# Altium schematic sheet AI8051U-34K64_LQFP48.SchDoc
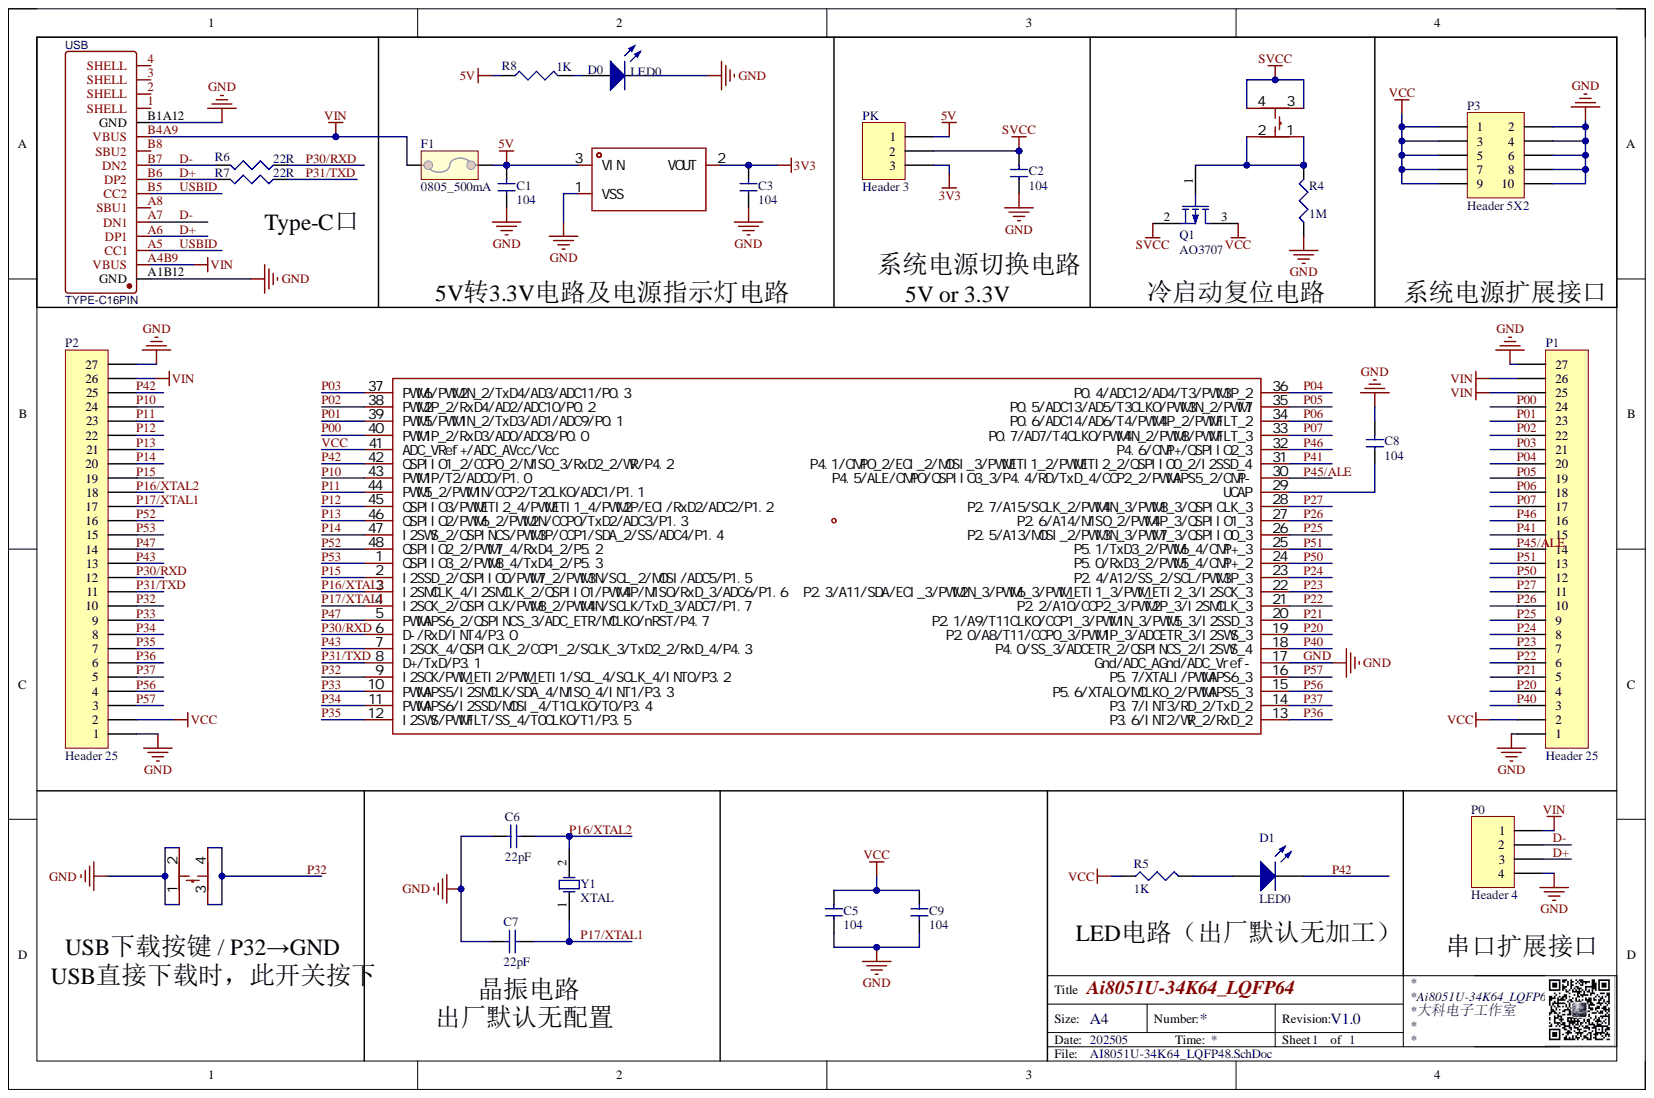
[diagram p0: AI8051U-34K64_LQFP48.SchDoc]

## Parts
C1  104  [C0805]
C2  104  [C0805]
C3  104  [C0805]
C5  104  [C0805]
C6  value 22pF  [C0805]  (hidden comment: Cap)
C7  22pF  [C0805]
C8  104  [C0805]
C9  104  [C0805]
D0  LED0  [D0805]
D1  LED0  [D0805]
F1  0805_500mA  [1206C]
P0  Header 4  [HDR1X4]
P1  Header 25  [HDR1X27]
P2  Header 25  [HDR1X27]
P3  Header 5X2  [HDR2X5-]
PK  Header 3  [HDR-TH_3P-P2.54-V-F]
Q1  AO3707  [SOT-23-3_L2.9-W1.6-P1.90-LS2.8-BR]
Q2  ME6211A33M3G-N  [SOT-23-3_L2.9-W1.6-P1.90-LS2.8-BR]  (value not drawn)
R4  value 1M  [R0805]
R5  value 1K  [R0805]
R6  value 22R  [R0805]
R7  value 22R  [R0805]
R8  value 1K  [R0805]
RST  TS3425BA  [SW-SMD_4P-L4.2-W3.2-P2.15-LS4.6-1]  (value not drawn)
SW  TS3425BA  [SW-SMD_4P-L4.2-W3.2-P2.15-LS4.6-1]  (value not drawn)
U1  AI8051U-34K64-QFP48  [LQFP48]  (value not drawn)
USB  TYPE-C16PIN  [TYPE-C]
Y1  XTAL  [X-SM49]

## Nets
3V3: C3.2 PK.3 Q2.2
5V: C1.2 F1.2 PK.1 Q2.3 R8.1
SVCC: C2.2 PK.2 Q1.2 RST.3 RST.4
VIN: F1.1 P0.1 P1.25 P1.26 P2.26 USB.A4B9(VBUS) USB.B4A9(VBUS)
D+: P0.3 R7.2 USB.A6(DP1) USB.B6(DP2)
D-: P0.2 R6.2 USB.A7(DN1) USB.B7(DN2)
GND: C1.1 C2.1 C3.1 C5.1 C6.1 C7.1 C8.2 C9.1 D0.2 P0.4 P1.1 P1.27 P2.1 P2.27 P3.2 P3.4 P3.6 P3.8 P3.10 Q2.1 R4.1 SW.1 SW.2 U1.17(Gnd/ADC_AGnd/ADC_Vref-) USB.A1B12(GND) USB.B1A12(GND)
P00: P1.24 U1.40(PWM1P_2/RxD3/AD0/ADC8/P0.0)
P01: P1.23 U1.39(PWM5/PWM1N_2/TxD3/AD1/ADC9/P0.1)
P02: P1.22 U1.38(PWM2P_2/RxD4/AD2/ADC10/P0.2)
P03: P1.21 U1.37(PWM6/PWM2N_2/TxD4/AD3/ADC11/P0.3)
P04: P1.20 U1.36(P0.4/ADC12/AD4/T3/PWM3P_2)
P05: P1.19 U1.35(P0.5/ADC13/AD5/T3CLKO/PWM3N_2/PWM7)
P06: P1.18 U1.34(P0.6/ADC14/AD6/T4/PWM4P_2/PWMFLT_2)
P07: P1.17 U1.33(P0.7/AD7/T4CLKO/PWM4N_2/PWM8/PWMFLT_3)
P10: P2.24 U1.43(PWM1P/T2/ADC0/P1.0)
P11: P2.23 U1.44(PWM5_2/PWM1N/CCP2/T2CLKO/ADC1/P1.1)
P12: P2.22 U1.45(QSPIIO3/PWMETI2_4/PWMETI1_4/PWM2P/ECI/RxD2/ADC2/P1.2)
P13: P2.21 U1.46(QSPIIO2/PWM6_2/PWM2N/CCP0/TxD2/ADC3/P1.3)
P14: P2.20 U1.47(I2SWS_2/QSPINCS/PWM3P/CCP1/SDA_2/SS/ADC4/P1.4)
P15: P2.19 U1.2(I2SSD_2/QSPIIO0/PWM7_2/PWM3N/SCL_2/MOSI/ADC5/P1.5)
P16/XTAL2: C6.2 P2.18 U1.3(I2SMCLK_4/I2SMCLK_2/QSPIIO1/PWM4P/MISO/RxD_3/ADC6/P1.6) Y1.2
P17/XTAL1: C7.2 P2.17 U1.4(I2SCK_2/QSPICLK/PWM8_2/PWM4N/SCLK/TxD_3/ADC7/P1.7) Y1.1
P20: P1.4 U1.19(P2.0/A8/T11/CCP0_3/PWM1P_3/ADCETR_3/I2SWS_3)
P21: P1.5 U1.20(P2.1/A9/T11CLKO/CCP1_3/PWM1N_3/PWM5_3/I2SSD_3)
P22: P1.6 U1.21(P2.2/A10/CCP2_3/PWM2P_3/I2SMCLK_3)
P23: P1.7 U1.22(P2.3/A11/SDA/ECI_3/PWM2N_3/PWM6_3/PWM_ETI1_3/PWM_ETI2_3/I2SCK_3)
P24: P1.8 U1.23(P2.4/A12/SS_2/SCL/PWM3P_3)
P25: P1.9 U1.26(P2.5/A13/MOSI_2/PWM3N_3/PWM7_3/QSPIIO0_3)
P26: P1.10 U1.27(P2.6/A14/MISO_2/PWM4P_3/QSPIIO1_3)
P27: P1.11 U1.28(P2.7/A15/SCLK_2/PWM4N_3/PWM8_3/QSPICLK_3)
P30/RXD: P2.12 R6.1 U1.6(D-/RxD/INT4/P3.0)
P31/TXD: P2.11 R7.1 U1.8(D+/TxD/P3.1)
P32: P2.10 SW.3 SW.4 U1.9(I2SCK/PWM_ETI2/PWM_ETI1/SCL_4/SCLK_4/INT0/P3.2)
P33: P2.9 U1.10(PWMAPS5/I2SMCLK/SDA_4/MISO_4/INT1/P3.3)
P34: P2.8 U1.11(PWMAPS6/I2SSD/MOSI_4/T1CLKO/T0/P3.4)
P35: P2.7 U1.12(I2SWS/PWMFLT/SS_4/T0CLKO/T1/P3.5)
P36: P2.6 U1.13(P3.6/INT2/WR_2/RxD_2)
P37: P2.5 U1.14(P3.7/INT3/RD_2/TxD_2)
P40: P1.3 U1.18(P4.0/SS_3/ADCETR_2/QSPINCS_2/I2SWS_4)
P41: P1.15 U1.31(P4.1/CMPO_2/ECI_2/MOSI_3/PWMETI1_2/PWMETI2_2/QSPIIO0_2/I2SSD_4)
P42: D1.2 P2.25 U1.42(QSPIIO1_2/CCP0_2/MISO_3/RxD2_2/WR/P4.2)
P43: P2.13 U1.7(I2SCK_4/QSPICLK_2/CCP1_2/SCLK_3/TxD2_2/RxD_4/P4.3)
P45/ALE: P1.14 U1.30(P4.5/ALE/CMPO/QSPIIO3_3/P4.4/RD/TxD_4/CCP2_2/PWMAPS5_2/CMP-)
P46: P1.16 U1.32(P4.6/CMP+/QSPIIO2_3)
P47: P2.14 U1.5(PWMAPS6_2/QSPINCS_3/ADC_ETR/MCLKO/nRST/P4.7)
P50: P1.12 U1.24(P5.0/RxD3_2/PWM5_4/CMP+_2)
P51: P1.13 U1.25(P5.1/TxD3_2/PWM6_4/CMP+_3)
P52: P2.16 U1.48(QSPIIO2_2/PWM7_4/RxD4_2/P5.2)
P53: P2.15 U1.1(QSPIIO3_2/PWM8_4/TxD4_2/P5.3)
P56: P2.4 U1.15(P5.6/XTALO/MCLKO_2/PWMAPS5_3)
P57: P2.3 U1.16(P5.7/XTALI/PWMAPS6_3)
USBID: USB.A5(CC1) USB.B5(CC2)
VCC: C5.2 C9.2 P1.2 P2.2 P3.1 P3.3 P3.5 P3.7 P3.9 Q1.3 R5.1 U1.41(ADC_VRef+/ADC_AVcc/Vcc)
NetC8_1: C8.1 U1.29(UCAP)
NetD0_1: D0.1 R8.2
NetD1_1: D1.1 R5.2
NetQ1_1: Q1.1 R4.2 RST.1 RST.2

Not connected: USB 1(SHELL) 2(SHELL) 3(SHELL) 4(SHELL) A8(SBU1) B8(SBU2)

## Library data of the parts
C1-C3 C5 C8 C9 = CAP CN: Component Kind: Standard
C6 C7 = Cap: 无极性电容; Component Kind: Standard; LatestRevisionDate: 17-Jul-2002; LatestRevisionNote: Re-released for DXP Platform.; PackageReference: RAD-0.3; Published: 8-Jun-2000; Library Name: Fszhang.IntLib; Publisher: Altium Limited
  C7: Value: 22pF
D0 D1 = LED0: Typical INFRARED GaAs LED; Component Kind: Standard; LatestRevisionDate: 08-Jul-2005; LatestRevisionNote: Mech Layer 1 removed.; Library Name: Miscellaneous Devices.IntLib; PackageDescription: LED; 2 Leads; PackageReference: LED-0; Published: 8-Jun-2000; Simulation: LED0; Publisher: Altium Limited
F1 = Fuse: Component Kind: Standard; Description: Fuse; Library Name: 安中医库2.0.SCHLIB
P0 = Header 4: Header, 4-Pin; Component Kind: Standard; LatestRevisionDate: 17-Jul-2002; LatestRevisionNote: Re-released for DXP Platform.; Library Name: Miscellaneous Connectors.IntLib; Signal Integrity: Connector; Publisher: Altium Limited
P1 P2 = Header 25: Header, 25-Pin; Component Kind: Standard; LatestRevisionDate: 17-Jul-2002; LatestRevisionNote: Re-released for DXP Platform.; Library Name: STC8G_Core.SCHLIB; Signal Integrity: Connector; Publisher: Altium Limited
P3 = Header 5X2: Header, 5-Pin, Dual row; Component Kind: Standard; LatestRevisionDate: 17-Jul-2002; LatestRevisionNote: Re-released for DXP Platform.; Library Name: Miscellaneous Connectors.IntLib; Signal Integrity: Connector; Publisher: Altium Limited
PK = Header 3: Header, 3-Pin; Component Kind: Standard; LatestRevisionDate: 17-Jul-2002; LatestRevisionNote: Re-released for DXP Platform.; Library Name: Miscellaneous Connectors.IntLib; Signal Integrity: Connector; Publisher: Altium Limited
Q1 = MOSFET-P: P-Channel MOSFET; Component Kind: Standard; LatestRevisionDate: 17-Jul-2002; LatestRevisionNote: Re-released for DXP Platform.; Library Name: Miscellaneous Devices.IntLib; PackageDescription: TO, Flat Index; 3 In-Line, Axial Leads; Body Dia. 4.6mm; Lead Dia. 0.5 mm (max); PackageReference: E3; PackageVersion: 1995/1996; Published: 8-Jun-2000; Simulation: PMOS; Publisher: Altium Limited
Q2 = ME6211A33M3G-N: Manufacturer: MICRONE(南京微盟); Add into BOM: yes; Dropout Voltage: 260mV@(200mA); MAX Output Current: 500mA; Maximum Input Voltage: 6V; Number of Outputs: 1; Output Polarity: 正极; Output Type: 固定; Output Voltage: 3.3V; Power Supply Rejection Ratio (PSRR): 70dB; Component Kind: Standard; Convert to PCB: yes; Datasheet: https://atta.szlcsc.com/upload/public/pdf/source/20180224/C168807_15194366627921363679.pdf; Device: ME6211A33M3G-N; JLCPCB Part Class: Extended Part; LCSC Part Name: 输入6V 输出3.3V 500mA; Manufacturer Part: ME6211A33M3G-N; PCB3D: SOT-23-3L_L2.9-W1.6-H1.1-LS2.8-P0.95; Supplier: LCSC; Supplier Footprint: SOT-23; Supplier Part: C168807; Symbol: ME6211A33M3G-N
R4 R6 R7 = Res3: 1M; Component Kind: Standard; LatestRevisionDate: 29-May-2009; LatestRevisionNote: IPC-7351 Footprint Added.; Library Name: Miscellaneous Devices.IntLib; PackageDescription: Chip Resistor; PackageReference: J1-0603; Published: 8-Jun-2000; Signal Integrity: Res; Simulation: RESISTOR; Publisher: Altium Limited
R5 R8 = Res3: Resistor; Component Kind: Standard; LatestRevisionDate: 29-May-2009; LatestRevisionNote: IPC-7351 Footprint Added.; Library Name: Miscellaneous Devices.IntLib; PackageDescription: Chip Resistor; PackageReference: J1-0603; Published: 8-Jun-2000; Signal Integrity: Res; Simulation: RESISTOR; Publisher: Altium Limited
RST SW = TS3425BA: Manufacturer: SHOU HAN(首韩); Actuator Style: 椭圆形按钮; Actuator/Cap Color: 白色; Add into BOM: yes; Circuit: 单刀单掷; Contact Current: 50mA; Insulation Resistance: 100MΩ; Mechanical Life: 10万次; Operating Force: 250gf; Pin Style: J型引脚; Strike Gundam: 不带; Switch Height: 2.5mm; Switch Length: 4.2mm; Switch Width: 3.2mm; Voltage Rating (DC): 12V; Component Kind: Standard; Convert to PCB: yes; Datasheet: https://atta.szlcsc.com/upload/public/pdf/source/20210107/C2681476_BF217B2301F0070AA49504CB0BFDE895.pdf; Device: TS3425BA; JLCPCB Part Class: Extended Part; LCSC Part Name: 4.2*3.2*2.5mm 立贴 轻触开关; Manufacturer Part: TS3425BA; Mounting Style: 立贴; Operating Temperature: -20℃~+85℃; PCB3D: SW-SMD_4P-L4.2-W3.2-P2.15-LS4.6-1; Supplier: LCSC; Supplier Footprint: SMD; Supplier Part: C2681476; Symbol: TS3425BA
U1 = AI8051U-34K64-QFP48: Manufacturer: STC; Add into BOM: yes; Component Kind: Standard; Convert to PCB: yes; Datasheet: https://atta.szlcsc.com/upload/public/pdf/source/20241012/98E0A3F17C9CC280218AE3F266CCDE47.pdf; Device: AI8051U-34K64-QFP48; JLCPCB Part Class: Extended Part; LCSC Part Name: AI8051U-34K64-QFP48; Library Name: STC8G_Core.SCHLIB; Manufacturer Part: AI8051U-34K64-QFP48; Supplier: LCSC; Supplier Footprint: QFP-48; Supplier Part: C41427635; Symbol: AI8051U-34K64-QFP48
USB = TYPE-C16PIN: BOM_Manufacturer: SHOU HAN; Contributor: LCSC; BOM_Manufacturer Part: TYPE-C16PIN; BOM_Supplier: LCSC; BOM_Supplier Part: C393939; Component Kind: Standard; spicePre: U
Y1 = XTAL: Crystal Oscillator; Component Kind: Standard; LatestRevisionDate: 17-Jul-2002; LatestRevisionNote: Re-released for DXP Platform.; Library Name: Miscellaneous Devices.IntLib; PackageDescription: Crystal, Thru-Hole; 2 Leads; Body 3.1 x 8.2 mm (Dia.xH); PackageReference: R38; Published: 8-Jun-2000; Simulation: XTAL; Publisher: Altium Limited
